# Altium schematic sheet 08_power.SchDoc(08_power)
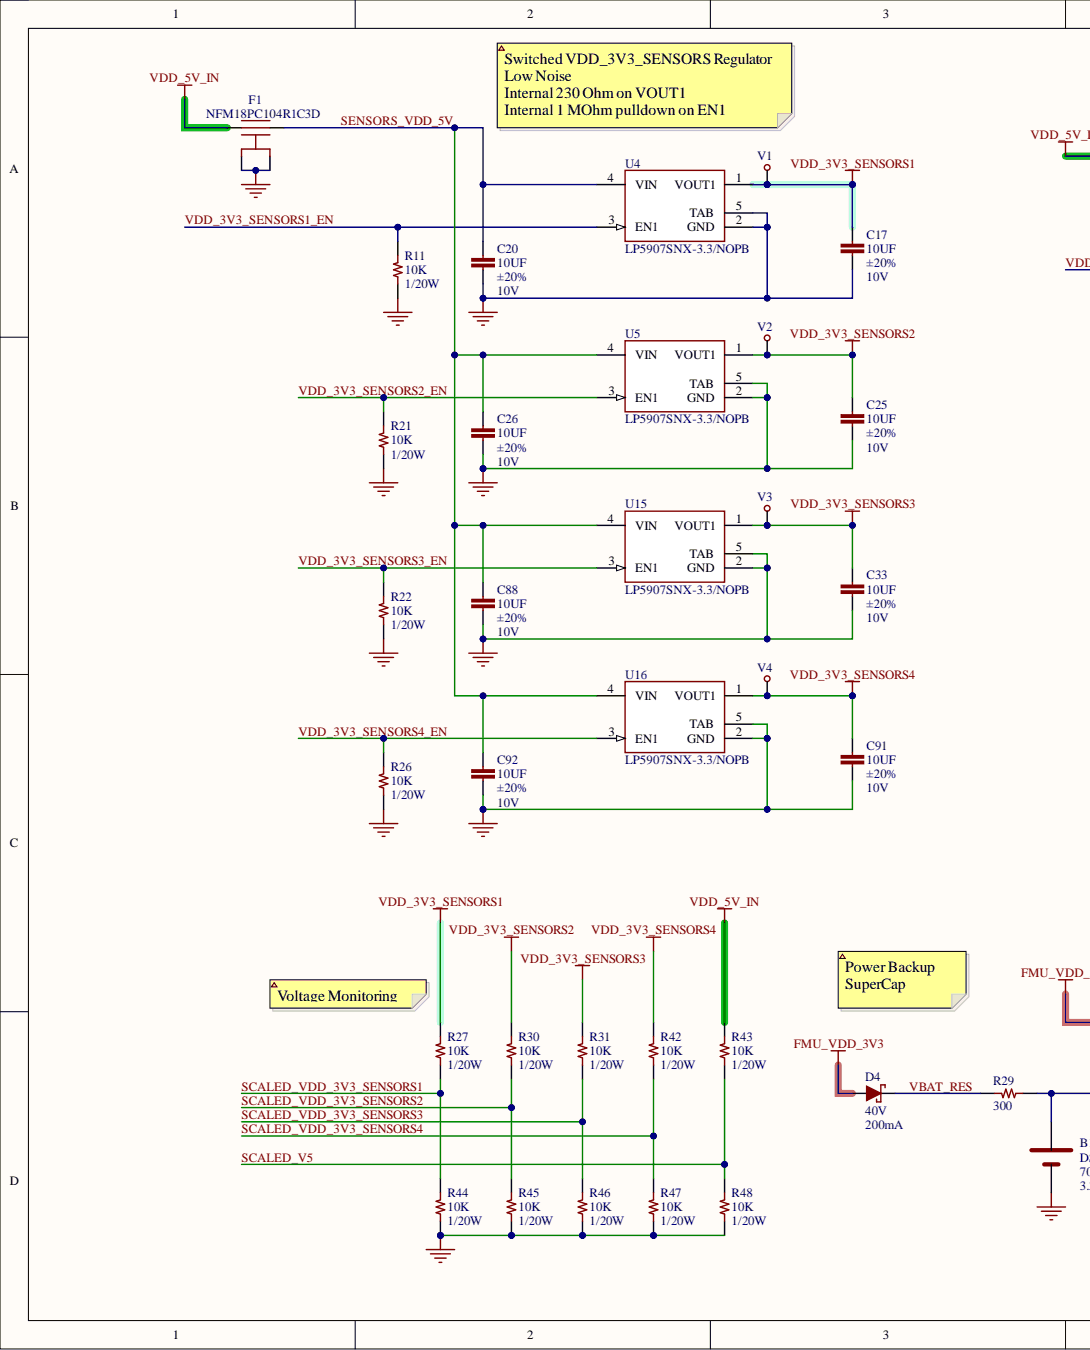
[diagram p0: 08_power.SchDoc, left]
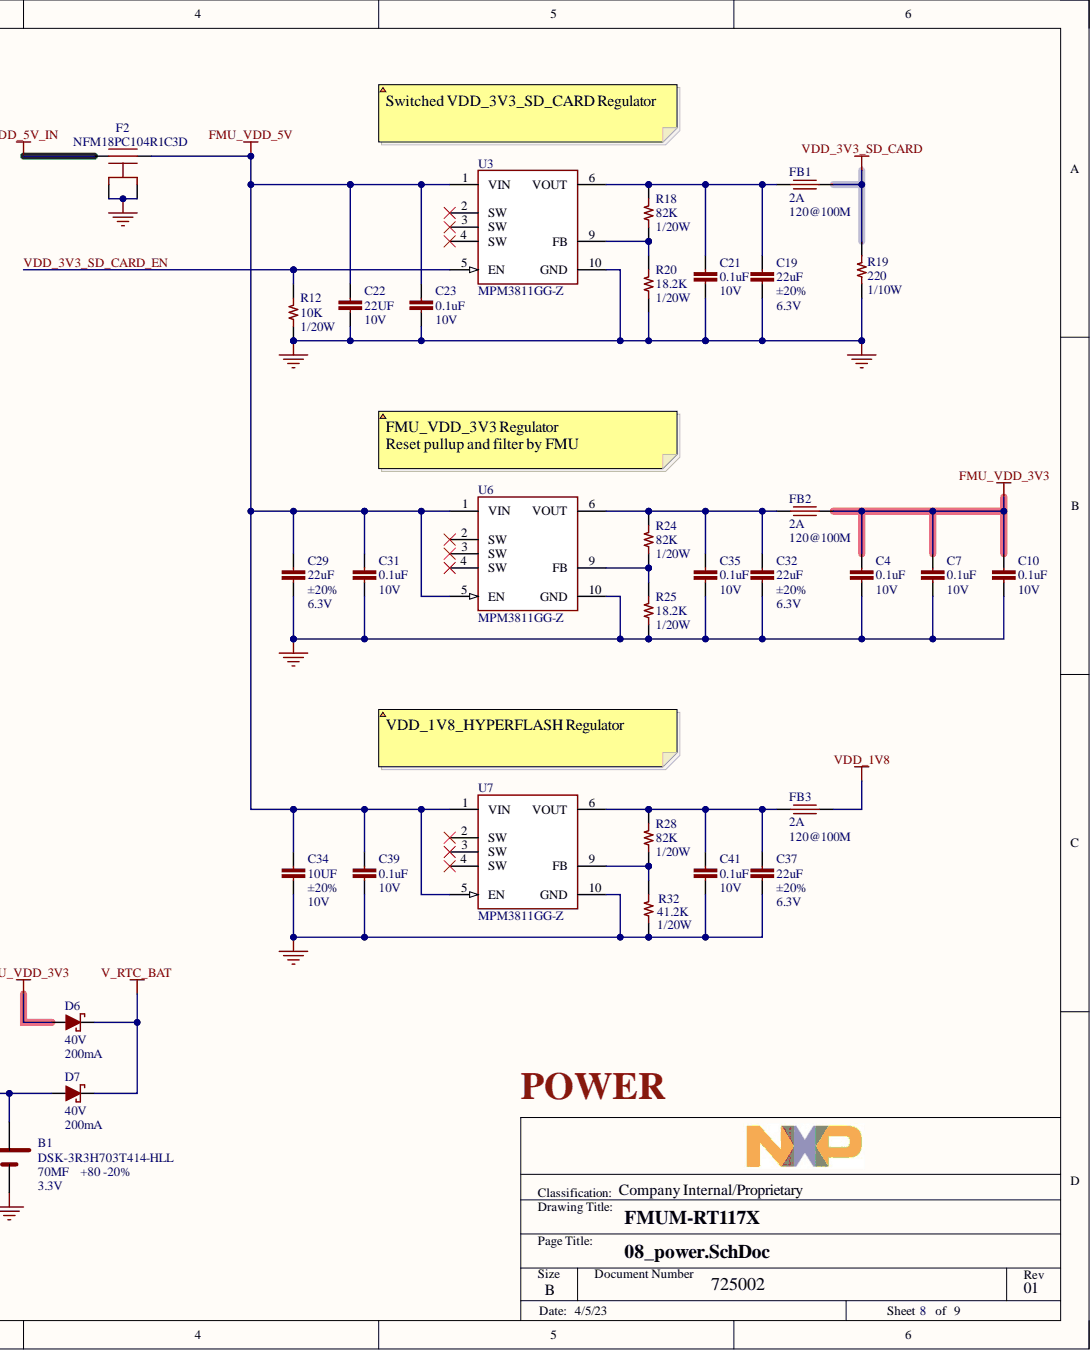
[diagram p1: 08_power.SchDoc, right]

## Parts
B1  value 70MF  Manufacturer Part Number: DSK-3R3H703T414-HLL  Voltage - Rated: 3.3V  [ELNA_DSK-3R3H703T414-HLL]  (hidden comment: BATT SUPERCAP 3.3V 70MF SMD)
C4  value 0.1uF  Voltage - Rated: 10V  [CAPC60X30X33L15N]  (hidden comment: CAP CER 0.1uF 20% 10V X5R 0201)
C7  value 0.1uF  Voltage - Rated: 10V  [CAPC60X30X33L15N]  (hidden comment: CAP CER 0.1uF 20% 10V X5R 0201)
C10  value 0.1uF  Voltage - Rated: 10V  [CAPC60X30X33L15N]  (hidden comment: CAP CER 0.1uF 20% 10V X5R 0201)
C17  value 10UF  Tolerance: ±20%  Voltage - Rated: 10V  [CAPC100X50X56L25N]  (hidden comment: CAP CER 10UF 20% 10V X5R 0402)
C19  value 22uF  Tolerance: ±20%  Voltage - Rated: 6.3V  [CAPC100X50X56L25N_NO_SILK]  (hidden comment: CAP CER 22UF 20% 6.3V X5R 0402)
C20  value 10UF  Tolerance: ±20%  Voltage - Rated: 10V  [CAPC100X50X56L25N]  (hidden comment: CAP CER 10UF 20% 10V X5R 0402)
C21  value 0.1uF  Voltage - Rated: 10V  [CAPC60X30X33L15N]  (hidden comment: CAP CER 0.1uF 20% 10V X5R 0201)
C22  value 22UF  Voltage - Rated: 10V  [CAPC1608X90L30N]  (hidden comment: CAP CER 22UF 10V X5R 0603)
C23  value 0.1uF  Voltage - Rated: 10V  [CAPC60X30X33L15N]  (hidden comment: CAP CER 0.1uF 20% 10V X5R 0201)
C25  value 10UF  Tolerance: ±20%  Voltage - Rated: 10V  [CAPC100X50X56L25N]  (hidden comment: CAP CER 10UF 20% 10V X5R 0402)
C26  value 10UF  Tolerance: ±20%  Voltage - Rated: 10V  [CAPC100X50X56L25N]  (hidden comment: CAP CER 10UF 20% 10V X5R 0402)
C29  value 22uF  Tolerance: ±20%  Voltage - Rated: 6.3V  [CAPC100X50X56L25N_NO_SILK]  (hidden comment: CAP CER 22UF 20% 6.3V X5R 0402)
C31  value 0.1uF  Voltage - Rated: 10V  [CAPC60X30X33L15N]  (hidden comment: CAP CER 0.1uF 20% 10V X5R 0201)
C32  value 22uF  Tolerance: ±20%  Voltage - Rated: 6.3V  [CAPC100X50X56L25N_NO_SILK]  (hidden comment: CAP CER 22UF 20% 6.3V X5R 0402)
C33  value 10UF  Tolerance: ±20%  Voltage - Rated: 10V  [CAPC100X50X56L25N]  (hidden comment: CAP CER 10UF 20% 10V X5R 0402)
C34  value 10UF  Tolerance: ±20%  Voltage - Rated: 10V  [CAPC100X50X56L25N]  (hidden comment: CAP CER 10UF 20% 10V X5R 0402)
C35  value 0.1uF  Voltage - Rated: 10V  [CAPC60X30X33L15N]  (hidden comment: CAP CER 0.1uF 20% 10V X5R 0201)
C37  value 22uF  Tolerance: ±20%  Voltage - Rated: 6.3V  [CAPC100X50X56L25N_NO_SILK]  (hidden comment: CAP CER 22UF 20% 6.3V X5R 0402)
C39  value 0.1uF  Voltage - Rated: 10V  [CAPC60X30X33L15N]  (hidden comment: CAP CER 0.1uF 20% 10V X5R 0201)
C41  value 0.1uF  Voltage - Rated: 10V  [CAPC60X30X33L15N]  (hidden comment: CAP CER 0.1uF 20% 10V X5R 0201)
C88  value 10UF  Tolerance: ±20%  Voltage - Rated: 10V  [CAPC100X50X56L25N]  (hidden comment: CAP CER 10UF 20% 10V X5R 0402)
C91  value 10UF  Tolerance: ±20%  Voltage - Rated: 10V  [CAPC100X50X56L25N]  (hidden comment: CAP CER 10UF 20% 10V X5R 0402)
C92  value 10UF  Tolerance: ±20%  Voltage - Rated: 10V  [CAPC100X50X56L25N]  (hidden comment: CAP CER 10UF 20% 10V X5R 0402)
D4  Current: 200mA  Voltage: 40V  [SODFL120X80X70L30X30]  (hidden comment: DIODE SCHOTTKY 40V 200MA SOD523)
D6  Voltage: 40V  [SODFL120X80X70L30X30]  (hidden comment: DIODE SCHOTTKY 40V 200MA SOD523)
D7  Current: 200mA  Voltage: 40V  [SODFL120X80X70L30X30]  (hidden comment: DIODE SCHOTTKY 40V 200MA SOD523)
F1  Manufacturer Part Number: NFM18PC104R1C3D  [NFM18PC104R1C3_0603_NO_VIA]  (hidden comment: FILTER CAP FEEDTHRU 0.1UF 20% 16V 0603)
F2  Manufacturer Part Number: NFM18PC104R1C3D  [NFM18PC104R1C3_0603_NO_VIA]  (hidden comment: FILTER CAP FEEDTHRU 0.1UF 20% 16V 0603)
FB1  value 120@100M  Current: 2A  [BEADC100X50X55L25]  (hidden comment: IND FERRITE 120@100M 2A 0402)
FB2  value 120@100M  Current: 2A  [BEADC100X50X55L25]  (hidden comment: IND FERRITE 120@100M 2A 0402)
FB3  value 120@100M  Current: 2A  [BEADC100X50X55L25]  (hidden comment: IND FERRITE 120@100M 2A 0402)
R11  value 10K  Power: 1/20W  [RESC60X30X33L15N]  (hidden comment: RES SMD 10K OHM 1% 1/20W 0201)
R12  value 10K  Power: 1/20W  [RESC60X30X33L15N]  (hidden comment: RES SMD 10K OHM 1% 1/20W 0201)
R18  value 82K  Power: 1/20W  [RESC60X30X33L15N]  (hidden comment: RES SMD 82K OHM 1% 1/20W 0201)
R19  value 220  Power: 1/10W  [RESC1608X55L30N]  (hidden comment: RES SMD 220 OHM 1% 1/10W 0603)
R20  value 18.2K  Power: 1/20W  [RESC60X30X33L15N]  (hidden comment: RES SMD 18.2K OHM 1% 1/20W 0201)
R21  value 10K  Power: 1/20W  [RESC60X30X33L15N]  (hidden comment: RES SMD 10K OHM 1% 1/20W 0201)
R22  value 10K  Power: 1/20W  [RESC60X30X33L15N]  (hidden comment: RES SMD 10K OHM 1% 1/20W 0201)
R24  value 82K  Power: 1/20W  [RESC60X30X33L15N]  (hidden comment: RES SMD 82K OHM 1% 1/20W 0201)
R25  value 18.2K  Power: 1/20W  [RESC60X30X33L15N]  (hidden comment: RES SMD 18.2K OHM 1% 1/20W 0201)
R26  value 10K  Power: 1/20W  [RESC60X30X33L15N]  (hidden comment: RES SMD 10K OHM 1% 1/20W 0201)
R27  value 10K  Power: 1/20W  [RESC60X30X33L15N]  (hidden comment: RES SMD 10K OHM 1% 1/20W 0201)
R28  value 82K  Power: 1/20W  [RESC60X30X33L15N]  (hidden comment: RES SMD 82K OHM 1% 1/20W 0201)
R29  value 300  [RESC100X50X40L25]  (hidden comment: RES SMD 300 OHM 1% 1/16W 0402)
R30  value 10K  Power: 1/20W  [RESC60X30X33L15N]  (hidden comment: RES SMD 10K OHM 1% 1/20W 0201)
R31  value 10K  Power: 1/20W  [RESC60X30X33L15N]  (hidden comment: RES SMD 10K OHM 1% 1/20W 0201)
R32  value 41.2K  Power: 1/20W  [RESC60X30X33L15N]  (hidden comment: RES SMD 41.2K OHM 1% 1/20W 0201)
R42  value 10K  Power: 1/20W  [RESC60X30X33L15N]  (hidden comment: RES SMD 10K OHM 1% 1/20W 0201)
R43  value 10K  Power: 1/20W  [RESC60X30X33L15N]  (hidden comment: RES SMD 10K OHM 1% 1/20W 0201)
R44  value 10K  Power: 1/20W  [RESC60X30X33L15N]  (hidden comment: RES SMD 10K OHM 1% 1/20W 0201)
R45  value 10K  Power: 1/20W  [RESC60X30X33L15N]  (hidden comment: RES SMD 10K OHM 1% 1/20W 0201)
R46  value 10K  Power: 1/20W  [RESC60X30X33L15N]  (hidden comment: RES SMD 10K OHM 1% 1/20W 0201)
R47  value 10K  Power: 1/20W  [RESC60X30X33L15N]  (hidden comment: RES SMD 10K OHM 1% 1/20W 0201)
R48  value 10K  Power: 1/20W  [RESC60X30X33L15N]  (hidden comment: RES SMD 10K OHM 1% 1/20W 0201)
U3  Manufacturer Part Number: MPM3811GG-Z  [MONOLITHIC_QFN10_COMB_PADS]  (hidden comment: IC REG BUCK ADJ MPM3811GG-Z 1A 10QFN)
U4  Manufacturer Part Number: LP5907SNX-3.3/NOPB  [TI_DQN0004A]  (hidden comment: IC REG LINEAR 3.3V 250MA 4X2SON)
U5  Manufacturer Part Number: LP5907SNX-3.3/NOPB  [TI_DQN0004A]  (hidden comment: IC REG LINEAR 3.3V 250MA 4X2SON)
U6  Manufacturer Part Number: MPM3811GG-Z  [MONOLITHIC_QFN10_COMB_PADS]  (hidden comment: IC REG BUCK ADJ MPM3811GG-Z 1A 10QFN)
U7  Manufacturer Part Number: MPM3811GG-Z  [MONOLITHIC_QFN10_COMB_PADS]  (hidden comment: IC REG BUCK ADJ MPM3811GG-Z 1A 10QFN)
U15  Manufacturer Part Number: LP5907SNX-3.3/NOPB  [TI_DQN0004A]  (hidden comment: IC REG LINEAR 3.3V 250MA 4X2SON)
U16  Manufacturer Part Number: LP5907SNX-3.3/NOPB  [TI_DQN0004A]  (hidden comment: IC REG LINEAR 3.3V 250MA 4X2SON)
V1  TESTPOINT PAD NANO SMD  [TEST_PAD_0.3MM]  (value not drawn)  (no BOM)
V2  TESTPOINT PAD NANO SMD  [TEST_PAD_0.3MM]  (value not drawn)  (no BOM)
V3  TESTPOINT PAD NANO SMD  [TEST_PAD_0.3MM]  (value not drawn)  (no BOM)
V4  TESTPOINT PAD NANO SMD  [TEST_PAD_0.3MM]  (value not drawn)  (no BOM)

## Nets
FMU_VDD_3V3: C4.1 C7.1 C10.1 D4.A D6.A FB2.2
FMU_VDD_5V: C22.1 C23.1 C29.1 C31.1 C34.1 C39.1 F2.3 U3.1(VIN) U6.1(VIN) U6.5(EN) U7.1(VIN) U7.5(EN)
GND: B1.2 C4.2 C7.2 C10.2 C17.2 C19.2 C20.2 C21.2 C22.2 C23.2 C25.2 C26.2 C29.2 C31.2 C32.2 C33.2 C34.2 C35.2 C37.2 C39.2 C41.2 C88.2 C91.2 C92.2 F1.2A F1.2B F2.2A F2.2B R11.2 R12.2 R19.2 R20.2 R21.2 R22.2 R25.2 R26.2 R32.2 R44.2 R45.2 R46.2 R47.2 R48.2 U3.10(GND) U4.2(GND) U4.5(TAB) U5.2(GND) U5.5(TAB) U6.10(GND) U7.10(GND) U15.2(GND) U15.5(TAB) U16.2(GND) U16.5(TAB)
V_RTC_BAT: D6.C D7.C
VDD_1V8: FB3.2
VDD_3V3_SD_CARD: FB1.2 R19.1
VDD_3V3_SENSORS1: C17.1 R27.1 U4.1(VOUT1) V1.1
VDD_3V3_SENSORS2: C25.1 R30.1 U5.1(VOUT1) V2.1
VDD_3V3_SENSORS3: C33.1 R31.1 U15.1(VOUT1) V3.1
VDD_3V3_SENSORS4: C91.1 R42.1 U16.1(VOUT1) V4.1
VDD_5V_IN: F1.1 F2.1 R43.1
SCALED_V5: R43.2 R48.1
SCALED_VDD_3V3_SENSORS1: R27.2 R44.1
SCALED_VDD_3V3_SENSORS2: R30.2 R45.1
SCALED_VDD_3V3_SENSORS3: R31.2 R46.1
SCALED_VDD_3V3_SENSORS4: R42.2 R47.1
SENSORS_VDD_5V: C20.1 C26.1 C88.1 C92.1 F1.3 U4.4(VIN) U5.4(VIN) U15.4(VIN) U16.4(VIN)
VBAT_RES: D4.C R29.1
VDD_3V3_SD_CARD_EN: R12.1 U3.5(EN)
VDD_3V3_SENSORS1_EN: R11.1 U4.3(EN1)
VDD_3V3_SENSORS2_EN: R21.1 U5.3(EN1)
VDD_3V3_SENSORS3_EN: R22.1 U15.3(EN1)
VDD_3V3_SENSORS4_EN: R26.1 U16.3(EN1)
NetB1_1: B1.1 D7.A R29.2
NetC19_1: C19.1 C21.1 FB1.1 R18.1 U3.6(VOUT)
NetC32_1: C32.1 C35.1 FB2.1 R24.1 U6.6(VOUT)
NetC37_1: C37.1 C41.1 FB3.1 R28.1 U7.6(VOUT)
NetR18_2: R18.2 R20.1 U3.9(FB)
NetR24_2: R24.2 R25.1 U6.9(FB)
NetR28_2: R28.2 R32.1 U7.9(FB)

Not connected: U3 2(SW) 3(SW) 4(SW); U6 2(SW) 3(SW) 4(SW); U7 2(SW) 3(SW) 4(SW)

## Library data of the parts
B1 = BATT_SUPERCAP_70MF_3.3V_SMD: Manufacturer: Elna America; Battery Cell Size: Coin, 4.8mm; Tolerance: +80 -20%; Category: Battery Products; Component Kind: Standard; ComponentLink1Description: https://www.digikey.com/product-detail/en/elna-america/DSK-3R3H703T414-HLL/604-1165-1-ND/3283567; ComponentLink1URL: https://www.digikey.com/product-detail/en/elna-america/DSK-3R3H703T414-HLL/604-1165-1-ND/3283567; Description: BATT SUPERCAP 3.3V 70MF SMD; Part Status: Active; Pricing 1: 1=2.18 (USD); Stock 1: 31658; Supplier 1: Digi-Key; Supplier Part Number 1: 604-1165-1-ND; Library Name: NscDG_Integrated_Library.SchLib
C4 C7 C10 C21 C23 C31 C35 C39 C41 = CAP_0.1uF_10V_X5R_0201: Manufacturer: Murata Electronics; Manufacturer Part Number: GRM033R61A104ME15D; Category: Capacitors; Component Kind: Standard; ComponentLink1Description: https://www.digikey.com/en/products/detail/murata-electronics/GRM033R61A104ME15D/2175191; ComponentLink1URL: https://www.digikey.com/en/products/detail/murata-electronics/GRM033R61A104ME15D/2175191; Description: CAP CER 0.1uF 20% 10V X5R 0201; Operating Temperature: -55°C ~ 85°C; Packaging: Cut Tape (CT); Part Status: Active; Pricing 1: 1=0.10 (USD); Stock 1: 12909013; Supplier 1: Digi-Key; Supplier Part Number 1: 490-5405-1-ND; Tolerance: 20%; Library Name: NscDG_Integrated_Library.SchLib
C17 C20 C25 C26 C33 C34 C88 C91 C92 = CAP_10UF_20%_10V_X5R_0402: Manufacturer: Samsung Electro-Mechanics; Manufacturer Part Number: CL05A106MP5NUNC; Category: Capacitors; Component Kind: Standard; ComponentLink1Description: https://www.digikey.com/products/en?keywords=%091276-1450-1-ND; ComponentLink1URL: https://www.digikey.com/products/en?keywords=%091276-1450-1-ND; Description: CAP CER 10UF 20% 10V X5R 0402; Operating Temperature: -55°C ~ 85°C; Packaging: Tape & Reel (TR); Part Status: Active; Pricing 1: 1=0.53, 10=0.373, 100=0.23, 500=0.1761, 1000=0.15094, 2500=0.14375, 5000=0.13656 (USD); Ratings: -; Stock 1: 0; Supplier 1: Digi-Key; Supplier Part Number 1: 1276-1450-1-ND; Temperature Coefficient: X5R; Library Name: NscDG_Integrated_Library.SchLib
C19 C29 C32 C37 = CAP_22UF_6.3V_X5R_0402: Manufacturer: Samsung Electro-Mechanics; Manufacturer Part Number: CL05A226MQ5N6J8; Category: Capacitors; Component Kind: Standard; ComponentLink1Description: https://www.digikey.com/en/products/detail/samsung-electro-mechanics/CL05A226MQ5N6J8/7320595; ComponentLink1URL: https://www.digikey.com/en/products/detail/samsung-electro-mechanics/CL05A226MQ5N6J8/7320595; Description: CAP CER 22UF 20% 6.3V X5R 0402; Operating Temperature: -55°C ~ 85°C; Packaging: Cut Tape (CT); Part Status: Active; Pricing 1: 1=0.49 (USD); Stock 1: 965480; Supplier 1: Digi-Key; Supplier Part Number 1: 1276-7090-1-ND; Library Name: NscDG_Integrated_Library.SchLib
C22 = CAP_22UF_20%_10V_X5R_0603: Manufacturer: Samsung Electro-Mechanics; Manufacturer Part Number: CL10A226MP8NUNE; Tolerance: ±20%; Category: Capacitors; Component Kind: Standard; ComponentLink1Description: https://www.digikey.com/products/en/capacitors/ceramic-capacitors/60?k=CL10A226MP8NUN+E&k=&pkeyword=CL10A226MP8NUN+E&sv=0&pv7=2&sf=0&quantity=&ColumnSort=0&page=1&pageSize=25; ComponentLink1URL: https://www.digikey.com/products/en/capacitors/ceramic-capacitors/60?k=CL10A226MP8NUN+E&k=&pkeyword=CL10A226MP8NUN+E&sv=0&pv7=2&sf=0&quantity=&ColumnSort=0&page=1&pageSize=25; Description: CAP CER 22UF 10V X5R 0603; Operating Temperature: -55°C ~ 85°C; Packaging: Cut Tape (CT); Part Status: Active; Pricing 1: 1=0.81 (USD); Stock 1: 478571; Supplier 1: Digi-Key; Supplier Part Number 1: 1276-1274-1-ND; Library Name: NscDG_Integrated_Library.SchLib
D4 D6 D7 = DIODE_SCHOTTKY_LOW_VF_40V_200MA_SOD523: Manufacturer: Nexperia USA Inc.; Manufacturer Part Number: PMEG4002EB,115; Category: Discrete Semiconductor Products; Component Kind: Standard; ComponentLink1Description: https://www.digikey.com/products/en?keywords=1727-5208-1-ND; ComponentLink1URL: https://www.digikey.com/products/en?keywords=1727-5208-1-ND; Description: DIODE SCHOTTKY 40V 200MA SOD523; Packaging: Cut Tape (CT); Part Status: Active; Pricing 1: 1=0.5, 10=0.37, 100=0.2161, 500=0.14676, 1000=0.11502 (USD); Stock 1: 0; Supplier 1: Digi-Key; Supplier Part Number 1: 1727-5208-1-ND; Library Name: NscDG_Integrated_Library.SchLib
  D6: Current: 200mA
F1 F2 = FILTER_0.1uF_16V_2A_NO_VIA_0603: Manufacturer: Murata Electronics North America; Category: Filters; Component Kind: Standard; ComponentLink1Description: https://www.digikey.com/products/en/filters/feed-through-capacitors/845?k=NFM18PC104R1C3D&k=&pkeyword=NFM18PC104R1C3D&pv7=2&sf=0&quantity=&ColumnSort=0&page=1&pageSize=25; ComponentLink1URL: https://www.digikey.com/products/en/filters/feed-through-capacitors/845?k=NFM18PC104R1C3D&k=&pkeyword=NFM18PC104R1C3D&pv7=2&sf=0&quantity=&ColumnSort=0&page=1&pageSize=25; Description: FILTER CAP FEEDTHRU 0.1UF 20% 16V 0603; Operating Temperature: -55°C ~ 125°C; Packaging: Cut Tape (CT); Part Status: Active; Pricing 1: 1=0.19, 10=0.173, 25=0.1652, 50=0.1598, 100=0.1544, 250=0.1464, 500=0.12246, 1000=0.09503 (USD); Stock 1: 172860; Supplier 1: Digi-Key; Supplier Part Number 1: 490-6969-1-ND; Tolerance: ±20%; Voltage - Rated: 16V; Library Name: NscDG_Integrated_Library.SchLib
FB1-FB3 = IND_FERRITE_120@120M_2A_0402: Manufacturer: Murata Electronics North America; Manufacturer Part Number: BLM15PX121SN1D; Category: Inductors; Component Kind: Standard; ComponentLink1Description: https://www.digikey.com/product-detail/en/murata-electronics/BLM15PX121SN1D/490-9656-1-ND/4934752; ComponentLink1URL: https://www.digikey.com/product-detail/en/murata-electronics/BLM15PX121SN1D/490-9656-1-ND/4934752; Description: IND FERRITE 120@100M 2A 0402; Operating Temperature: -55°C ~ 125°C; Packaging: Cut Tape (CT); Part Status: Active; Pricing 1: 1=0.10 (USD); Stock 1: 98122; Supplier 1: Digi-Key; Supplier Part Number 1: 490-9656-1-ND; Library Name: NscDG_Integrated_Library.SchLib
  FB2 FB3: Value: 120@100M
R11 R12 R21 R22 R26 R27 R30 R31 R42-R48 = RES_10K_1%_1/20W_0201: Manufacturer: Yageo; Manufacturer Part Number: AC0201FR-0710KL; Category: Resistors; Component Kind: Standard; ComponentLink1Description: https://www.digikey.com/en/products/detail/yageo/AC0201FR-0710KL/5894228; ComponentLink1URL: https://www.digikey.com/en/products/detail/yageo/AC0201FR-0710KL/5894228; Description: RES SMD 10K OHM 1% 1/20W 0201; Operating Temperature: -55°C ~ 125°C; Packaging: Cut Tape (CT); Part Status: Active; Pricing 1: 1=0.1, 10=0.014, 100=0.0056, 1000=0.00253, 2500=0.0022, 5000=0.00181 (USD); Stock 1: 739618; Supplier 1: Digi-Key; Supplier Part Number 1: YAG3413CT-ND; Tolerance: ±1%; Library Name: NscDG_Integrated_Library.SchLib
R18 R24 R28 = RES_82K_1%_1/20W_0201: Manufacturer: Yageo; Manufacturer Part Number: RC0201FR-0782KL; Category: Resistors; Component Kind: Standard; ComponentLink1Description: https://www.digikey.com/en/products/detail/yageo/RC0201FR-0782KL/5280676; ComponentLink1URL: https://www.digikey.com/en/products/detail/yageo/RC0201FR-0782KL/5280676; Description: RES SMD 82K OHM 1% 1/20W 0201; Operating Temperature: -55°C ~ 125°C; Packaging: Cut Tape (CT); Part Status: Active; Pricing 1: 1=0.1, 10=0.014, 100=0.0056, 1000=0.00253, 2500=0.0022, 5000=0.00181 (USD); Stock 1: 167528; Supplier 1: Digi-Key; Supplier Part Number 1: YAG2816CT-ND; Tolerance: ±1%; Library Name: NscDG_Integrated_Library.SchLib
R19 = RES_220_1%_1/10W_0603: Manufacturer: Yageo; Manufacturer Part Number: RC0603FR-07220RL; Category: Resistors; Component Kind: Standard; ComponentLink1Description: https://www.digikey.com/products/en/resistors/chip-resistor-surface-mount/52?k=RC0603FR-07220RL&k=&pkeyword=RC0603FR-07220RL&pv7=2&sf=0&quantity=&ColumnSort=0&page=1&pageSize=25; ComponentLink1URL: https://www.digikey.com/products/en/resistors/chip-resistor-surface-mount/52?k=RC0603FR-07220RL&k=&pkeyword=RC0603FR-07220RL&pv7=2&sf=0&quantity=&ColumnSort=0&page=1&pageSize=25; Description: RES SMD 220 OHM 1% 1/10W 0603; Operating Temperature: -55°C ~ 155°C; Packaging: Cut Tape (CT); Part Status: Active; Pricing 1: 1=0.1, 10=0.015, 100=0.006, 1000=0.00268, 2500=0.00233 (USD); Stock 1: 1681680; Supplier 1: Digi-Key; Supplier Part Number 1: 311-220HRCT-ND; Tolerance: ±1%; Library Name: NscDG_Integrated_Library.SchLib
R20 R25 = RES_18.2K_1%_1/20W_0201: Manufacturer: Yageo; Manufacturer Part Number: RC0201FR-0718K2L; Category: Resistors; Component Kind: Standard; ComponentLink1Description: https://www.digikey.com/en/products/detail/yageo/RC0201FR-0718K2L/5280315; ComponentLink1URL: https://www.digikey.com/en/products/detail/yageo/RC0201FR-0718K2L/5280315; Description: RES SMD 18.2K OHM 1% 1/20W 0201; Operating Temperature: -55°C ~ 125°C; Packaging: Cut Tape (CT); Part Status: Active; Pricing 1: 1=0.1, 10=0.014, 100=0.0056, 1000=0.00253, 2500=0.0022, 5000=0.00181 (USD); Stock 1: 198828; Supplier 1: Digi-Key; Supplier Part Number 1: YAG2455CT-ND; Tolerance: ±1%; Library Name: NscDG_Integrated_Library.SchLib
R29 = RES_300_1%_1/16W_0402: Manufacturer: YAGEO; Manufacturer Part Number: RC0402FR-07300RL; Category: Resistors; Component Kind: Standard; ComponentLink1Description: https://www.digikey.com/en/products/detail/yageo/RC0402FR-07300RL/726589; ComponentLink1URL: https://www.digikey.com/en/products/detail/yageo/RC0402FR-07300RL/726589; Description: RES SMD 300 OHM 1% 1/16W 0402; Operating Temperature: -55°C ~ 155°C; Packaging: Cut Tape (CT); Part Status: Active; Power: 1/16W; Pricing 1: 1=0.1, 10=0.014, 100=0.0056, 1000=0.00253, 2500=0.0022, 5000=0.00181 (USD); Stock 1: 480483; Supplier 1: Digi-Key; Supplier Part Number 1: 311-300LRCT-ND; Tolerance: ±1%; Library Name: NscDG_Integrated_Library.SchLib
R32 = RES_41.2K_1%_1/20W_0201: Manufacturer: Yageo; Manufacturer Part Number: RC0201FR-0741K2L; Category: Resistors; Component Kind: Standard; ComponentLink1Description: https://www.digikey.com/en/products/detail/yageo/RC0201FR-0741K2L/5280522; ComponentLink1URL: https://www.digikey.com/en/products/detail/yageo/RC0201FR-0741K2L/5280522; Description: RES SMD 41.2K OHM 1% 1/20W 0201; Operating Temperature: -55°C ~ 125°C; Packaging: Cut Tape (CT); Part Status: Active; Pricing 1: 1=0.1, 10=0.014, 100=0.0056, 1000=0.00253, 2500=0.0022, 5000=0.00181 (USD); Stock 1: 85770; Supplier 1: Digi-Key; Supplier Part Number 1: YAG2662CT-ND; Tolerance: ±1%; Library Name: NscDG_Integrated_Library.SchLib
U3 U6 U7 = IC_MPM3811GG-Z_10QFN: Manufacturer: Monolithic Power Systems Inc.; Category: Integrated Circuits (ICs); Component Kind: Standard; ComponentLink1Description: https://www.digikey.com/product-detail/en/monolithic-power-systems-inc/MPM3811GG-Z/1589-1958-1-ND/9644348; ComponentLink1URL: https://www.digikey.com/product-detail/en/monolithic-power-systems-inc/MPM3811GG-Z/1589-1958-1-ND/9644348; Description: IC REG BUCK ADJ MPM3811GG-Z 1A 10QFN; Operating Temperature: -40°C ~ 125°C; Packaging: Cut Tape (CT); Part Status: Active; Pricing 1: 1=2.13 (USD); Stock 1: 1485; Supplier 1: Digi-Key; Supplier Part Number 1: 1589-1958-1-ND; Library Name: NscDG_Integrated_Library.SchLib
U4 U5 U15 U16 = IC_LP5907_4X2SON: Manufacturer: Texas Instruments; Category: Integrated Circuits (ICs); Component Kind: Standard; ComponentLink1Description: https://www.digikey.com/products/en/integrated-circuits-ics/pmic-voltage-regulators-linear/699?k=lp5907&k=&pkeyword=lp5907&pv1291=4239&sf=0&FV=ffe002bb%2C1c0002%2C1bcc0032%2C1f140000&quantity=&ColumnSort=0&page=1&pageSize=25; ComponentLink1URL: https://www.digikey.com/products/en/integrated-circuits-ics/pmic-voltage-regulators-linear/699?k=lp5907&k=&pkeyword=lp5907&pv1291=4239&sf=0&FV=ffe002bb%2C1c0002%2C1bcc0032%2C1f140000&quantity=&ColumnSort=0&page=1&pageSize=25; Description: IC REG LINEAR 3.3V 250MA 4X2SON; Operating Temperature: -40°C ~ 125°C; Packaging: Cut Tape (CT); Part Status: Active; Pricing 1: 1=0.55, 10=0.475, 100=0.3547, 500=0.27872, 1000=0.21537 (USD); Stock 1: 67178; Supplier 1: Digi-Key; Supplier Part Number 1: 296-39066-1-ND; Library Name: NscDG_Integrated_Library.SchLib
V1-V4 = TESTPOINT_PAD_NANO_SMD: CATEGORY: Test and Measurement; Description: TESTPOINT PAD NANO SMD; Manufacturer: N/A; Library Name: NscDG_Integrated_Library.SchLib
